# Altium schematic sheet [21] - MPSoC PWR AND GND PINS.SchDoc("[21] - MPSoC PWR AND GND PINS")
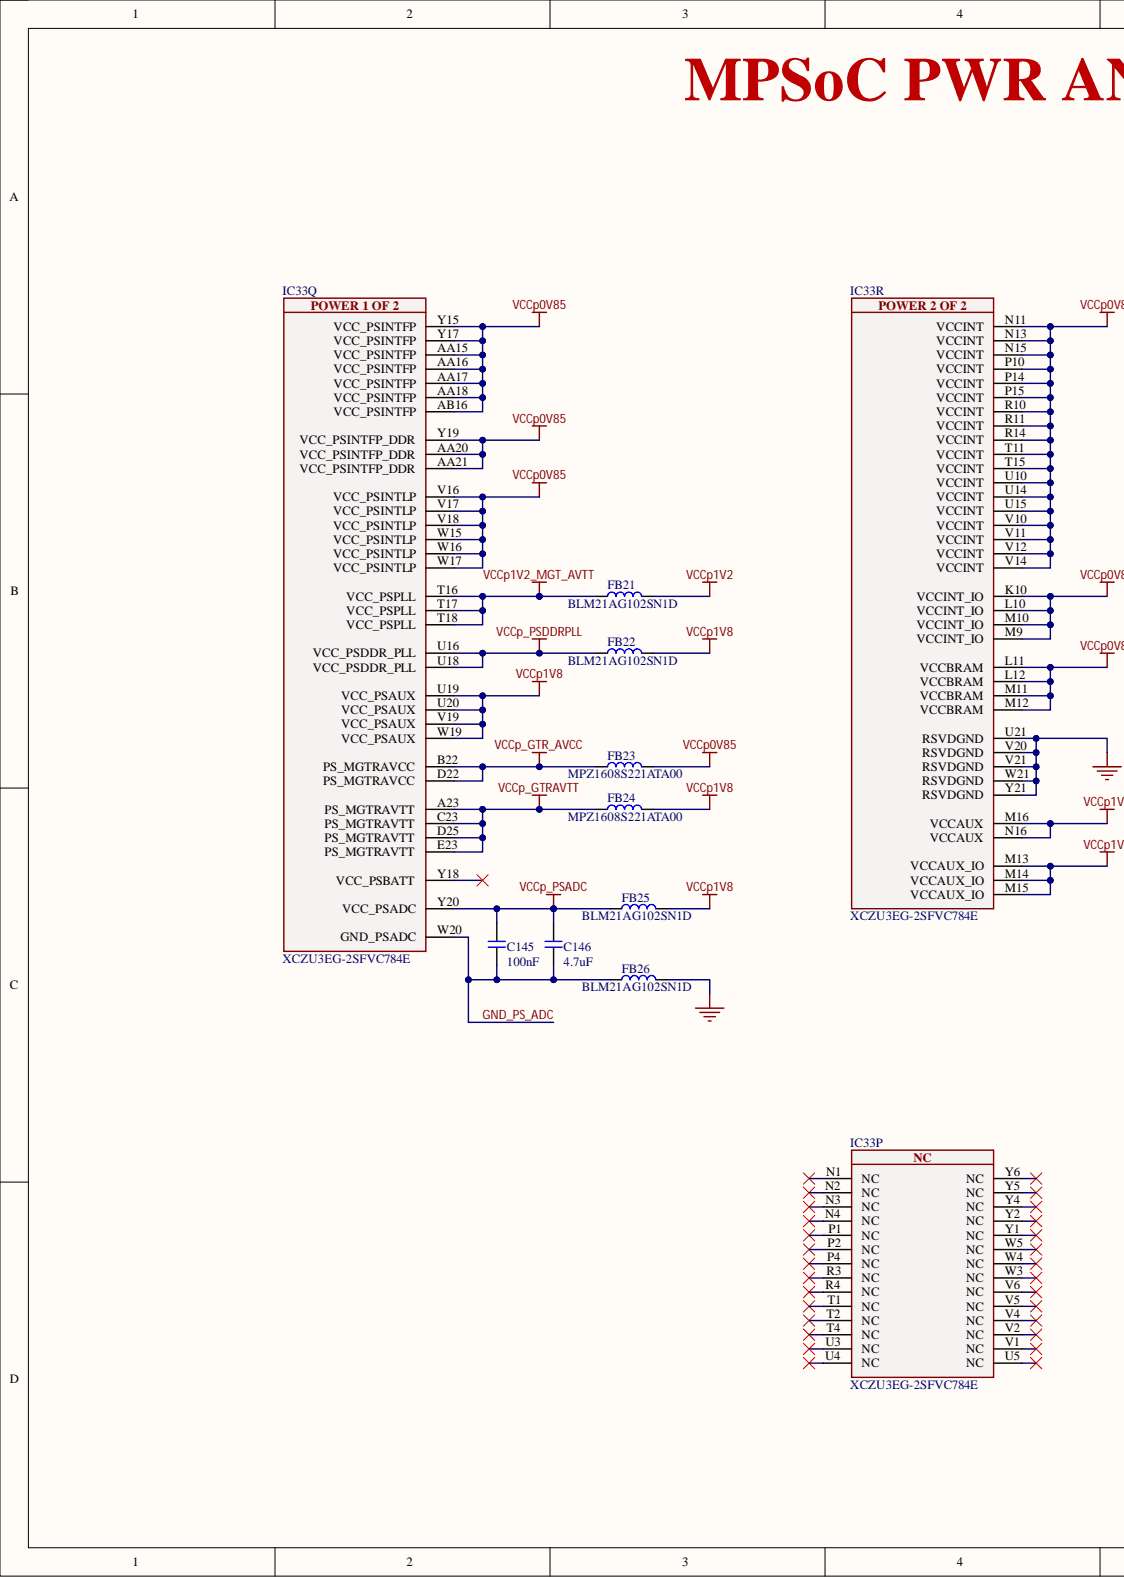
[diagram p0: [21] - MPSoC PWR AND GND PINS.SchDoc, left]
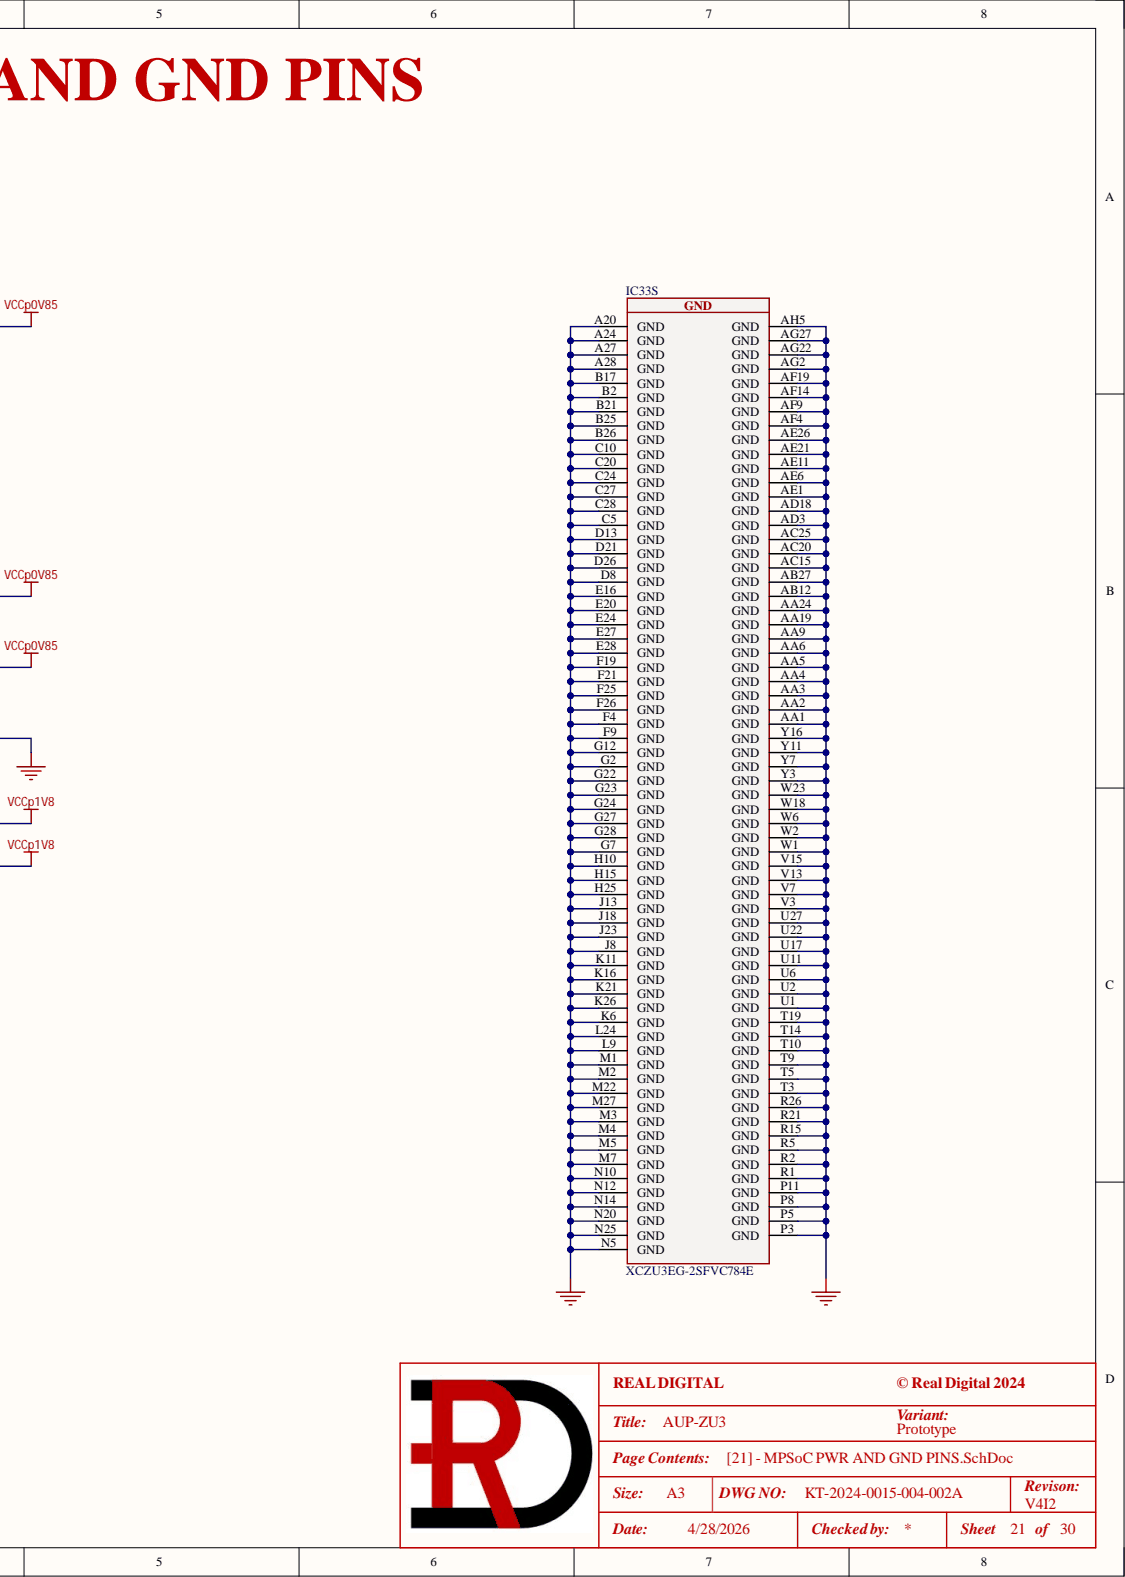
[diagram p1: [21] - MPSoC PWR AND GND PINS.SchDoc, right]

## Parts
C145  100nF  [C0402]
C146  4.7uF  [C0402]
FB21  BLM21AG102SN1D  [BLM21]
FB22  BLM21AG102SN1D  [BLM21]
FB23  MPZ1608S221ATA00  [MPZ1608]
FB24  MPZ1608S221ATA00  [MPZ1608]
FB25  BLM21AG102SN1D  [BLM21]
FB26  BLM21AG102SN1D  [BLM21]
IC33  XCZU3EG-2SFVC784E  [SFVC784 - AMD]  (parts P,Q,R,S)

## Nets
GND: FB26.2 IC33.A20(GND) IC33.A24(GND) IC33.A27(GND) IC33.A28(GND) IC33.AA1(GND) IC33.AA2(GND) IC33.AA3(GND) IC33.AA4(GND) IC33.AA5(GND) IC33.AA6(GND) IC33.AA9(GND) IC33.AA19(GND) IC33.AA24(GND) IC33.AB12(GND) IC33.AB27(GND) IC33.AC15(GND) IC33.AC20(GND) IC33.AC25(GND) IC33.AD3(GND) IC33.AD18(GND) IC33.AE1(GND) IC33.AE6(GND) IC33.AE11(GND) IC33.AE21(GND) IC33.AE26(GND) IC33.AF4(GND) IC33.AF9(GND) IC33.AF14(GND) IC33.AF19(GND) IC33.AG2(GND) IC33.AG22(GND) IC33.AG27(GND) IC33.AH5(GND) IC33.B2(GND) IC33.B17(GND) IC33.B21(GND) IC33.B25(GND) IC33.B26(GND) IC33.C5(GND) IC33.C10(GND) IC33.C20(GND) IC33.C24(GND) IC33.C27(GND) IC33.C28(GND) IC33.D8(GND) IC33.D13(GND) IC33.D21(GND) IC33.D26(GND) IC33.E16(GND) IC33.E20(GND) IC33.E24(GND) IC33.E27(GND) IC33.E28(GND) IC33.F4(GND) IC33.F9(GND) IC33.F19(GND) IC33.F21(GND) IC33.F25(GND) IC33.F26(GND) IC33.G2(GND) IC33.G7(GND) IC33.G12(GND) IC33.G22(GND) IC33.G23(GND) IC33.G24(GND) IC33.G27(GND) IC33.G28(GND) IC33.H10(GND) IC33.H15(GND) IC33.H25(GND) IC33.J8(GND) IC33.J13(GND) IC33.J18(GND) IC33.J23(GND) IC33.K6(GND) IC33.K11(GND) IC33.K16(GND) IC33.K21(GND) IC33.K26(GND) IC33.L9(GND) IC33.L24(GND) IC33.M1(GND) IC33.M2(GND) IC33.M3(GND) IC33.M4(GND) IC33.M5(GND) IC33.M7(GND) IC33.M22(GND) IC33.M27(GND) IC33.N5(GND) IC33.N10(GND) IC33.N12(GND) IC33.N14(GND) IC33.N20(GND) IC33.N25(GND) IC33.P3(GND) IC33.P5(GND) IC33.P8(GND) IC33.P11(GND) IC33.R1(GND) IC33.R2(GND) IC33.R5(GND) IC33.R15(GND) IC33.R21(GND) IC33.R26(GND) IC33.T3(GND) IC33.T5(GND) IC33.T9(GND) IC33.T10(GND) IC33.T14(GND) IC33.T19(GND) IC33.U1(GND) IC33.U2(GND) IC33.U6(GND) IC33.U11(GND) IC33.U17(GND) IC33.U21(RSVDGND) IC33.U22(GND) IC33.U27(GND) IC33.V3(GND) IC33.V7(GND) IC33.V13(GND) IC33.V15(GND) IC33.V20(RSVDGND) IC33.V21(RSVDGND) IC33.W1(GND) IC33.W2(GND) IC33.W6(GND) IC33.W18(GND) IC33.W21(RSVDGND) IC33.W23(GND) IC33.Y3(GND) IC33.Y7(GND) IC33.Y11(GND) IC33.Y16(GND) IC33.Y21(RSVDGND)
VCCp0V85: FB23.2 IC33.AA15(VCC_PSINTFP) IC33.AA16(VCC_PSINTFP) IC33.AA17(VCC_PSINTFP) IC33.AA18(VCC_PSINTFP) IC33.AA20(VCC_PSINTFP_DDR) IC33.AA21(VCC_PSINTFP_DDR) IC33.AB16(VCC_PSINTFP) IC33.K10(VCCINT_IO) IC33.L10(VCCINT_IO) IC33.L11(VCCBRAM) IC33.L12(VCCBRAM) IC33.M9(VCCINT_IO) IC33.M10(VCCINT_IO) IC33.M11(VCCBRAM) IC33.M12(VCCBRAM) IC33.N11(VCCINT) IC33.N13(VCCINT) IC33.N15(VCCINT) IC33.P10(VCCINT) IC33.P14(VCCINT) IC33.P15(VCCINT) IC33.R10(VCCINT) IC33.R11(VCCINT) IC33.R14(VCCINT) IC33.T11(VCCINT) IC33.T15(VCCINT) IC33.U10(VCCINT) IC33.U14(VCCINT) IC33.U15(VCCINT) IC33.V10(VCCINT) IC33.V11(VCCINT) IC33.V12(VCCINT) IC33.V14(VCCINT) IC33.V16(VCC_PSINTLP) IC33.V17(VCC_PSINTLP) IC33.V18(VCC_PSINTLP) IC33.W15(VCC_PSINTLP) IC33.W16(VCC_PSINTLP) IC33.W17(VCC_PSINTLP) IC33.Y15(VCC_PSINTFP) IC33.Y17(VCC_PSINTFP) IC33.Y19(VCC_PSINTFP_DDR)
VCCp1V2: FB21.2
VCCp1V2_MGT_AVTT: FB21.1 IC33.T16(VCC_PSPLL) IC33.T17(VCC_PSPLL) IC33.T18(VCC_PSPLL)
VCCp1V8: FB22.2 FB24.2 FB25.2 IC33.M13(VCCAUX_IO) IC33.M14(VCCAUX_IO) IC33.M15(VCCAUX_IO) IC33.M16(VCCAUX) IC33.N16(VCCAUX) IC33.U19(VCC_PSAUX) IC33.U20(VCC_PSAUX) IC33.V19(VCC_PSAUX) IC33.W19(VCC_PSAUX)
VCCp_GTR_AVCC: FB23.1 IC33.B22(PS_MGTRAVCC) IC33.D22(PS_MGTRAVCC)
VCCp_GTRAVTT: FB24.1 IC33.A23(PS_MGTRAVTT) IC33.C23(PS_MGTRAVTT) IC33.D25(PS_MGTRAVTT) IC33.E23(PS_MGTRAVTT)
VCCp_PSADC: C145.2 C146.2 FB25.1 IC33.Y20(VCC_PSADC)
VCCp_PSDDRPLL: FB22.1 IC33.U16(VCC_PSDDR_PLL) IC33.U18(VCC_PSDDR_PLL)
GND_PS_ADC: C145.1 C146.1 FB26.1 IC33.W20(GND_PSADC)

Not connected: IC33 N1(NC) N2(NC) N3(NC) N4(NC) P1(NC) P2(NC) P4(NC) R3(NC) R4(NC) T1(NC) T2(NC) T4(NC) U3(NC) U4(NC) U5(NC) V1(NC) V2(NC) V4(NC) V5(NC) V6(NC) W3(NC) W4(NC) W5(NC) Y1(NC) Y2(NC) Y4(NC) Y5(NC) Y6(NC) Y18(VCC_PSBATT)

## Library data of the parts
C145 = GRM155R71E104KE14J: CAP CER 0.1uF 25V X7R 0402; Manufacturer 1: Murata Electronics; .Checked_PCB: AC; .Creator: RV; C P/N: RD-111-0006; LoadStatus: Load; Tolerance: 10%; Category: Capacitors; Component Kind: Standard; Library Name: KT-RealDigital.IntLib; Manufacturer 1 Part Number: GRM155R71E104KE14J; Temperature Coefficient: X7R; Voltage - Rated: 25V; .Checked_SCH: AC
C146 = GRM155R60J475ME47J: CAP CER 4.7uF 6.3V X5R 0402; Manufacturer 1: Murata Electronics; .Checked_PCB: RV; C P/N: RD-111-0022; LoadStatus: Load; Tolerance: 20%; Category: Capacitors; Component Kind: Standard; Library Name: KT-RealDigital.IntLib; Manufacturer 1 Part Number: GRM155R60J475ME47J; Temperature Coefficient: X5R; Voltage - Rated: 6.3V; .Checked_SCH: RV; .Creator: RV
FB21 FB22 FB25 FB26 = BLM21AG102SN1D: FERRITE BEAD 1 KOHM 0805 1LN; Manufacturer 1: Murata Electronics; .Checked_PCB: RV; C P/N: CY-131-0087; LoadStatus: Load; Category: Ferrite Beads; Component Kind: Standard; Library Name: KT-RealDigital.IntLib; Manufacturer 1 Part Number: BLM21AG102SN1D; .Checked_SCH: RV; .Creator: RV
FB23 FB24 = MPZ1608S221ATA00: FERRITE BEAD 220 OHM 0603 1LN; Manufacturer 1: TDK Corporation; .Checked_PCB: AC; .Checked_SCH: RV; C P/N: CY-121-0068; LoadStatus: Load; Category: Ferrite Beads; Component Kind: Standard; Library Name: KT-RealDigital.IntLib; Manufacturer 1 Part Number: MPZ1608S221ATA00; .Creator: RV
IC33 = XCZU3EG-2SFVC784E: Quad ARM® Cortex®-A53 MPCore™ with CoreSight™, Dual ARM®Cortex™-R5 with CoreSight™, ARM Mali™-400 MP2 System On Chip (SOC) IC Zynq® UltraScale+™ MPSoC EG Zynq®UltraScale+™ FPGA, 154K+ Logic Cells 533MHz, 600MHz, 1.3GHz 784-FCBGA (23x23); Manufacturer 1: AMD; .Checked_PCB: RV; C P/N: RD-126-0101-C; LoadStatus: Load; Category: IC; Component Kind: Standard; Library Name: KT-RealDigital.IntLib; Manufacturer 1 Part Number: XCZU3EG-2SFVC784E; .Checked_SCH: RV; .Creator: RV
